# Altium schematic sheet TriangleGeneratorHammondFrontPnlRev1b.SchDoc(TriangleGeneratorHammondFrontPnlRev1b)
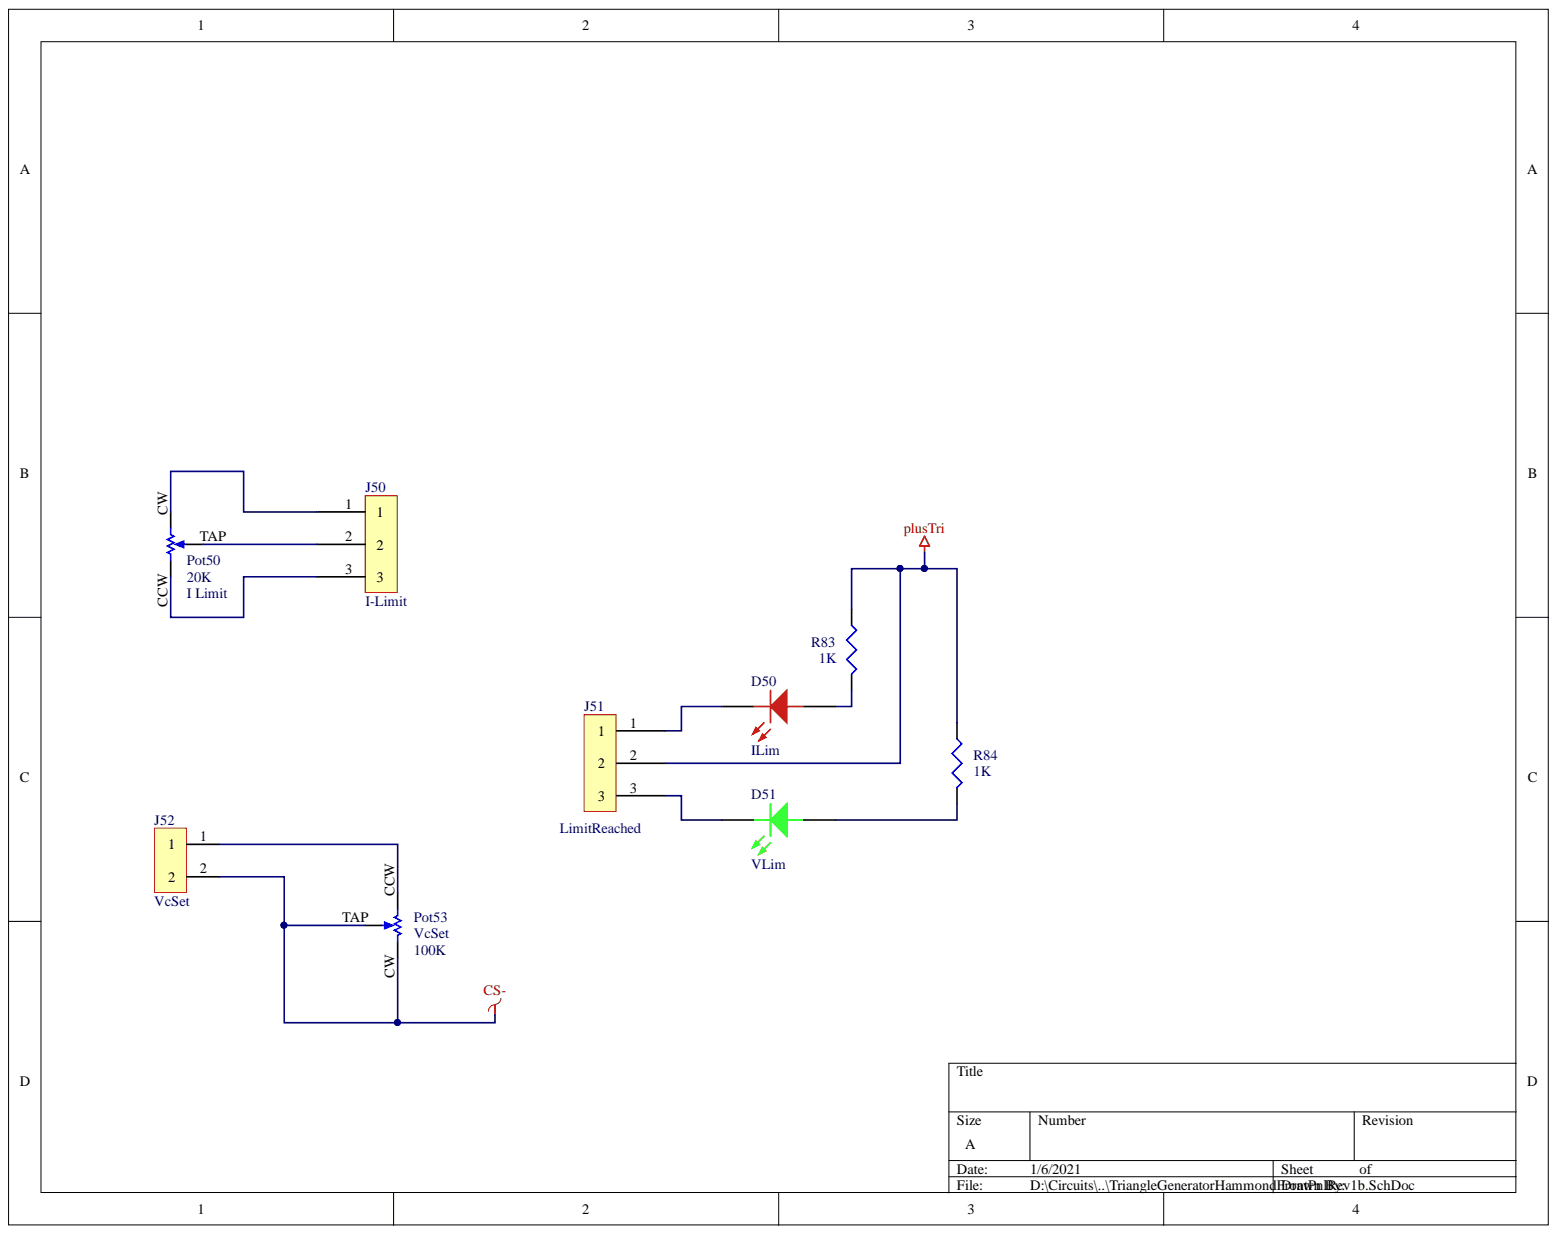
[diagram p0: TriangleGeneratorHammondFrontPnlRev1b.SchDoc]

## Parts
D50  ILim  [LED-RED-3MM]
D51  VLim  [LED-GRN-3MM]
J50  I-Limit  [DTA-100-3]
J51  LimitReached  [DTA-100-3]
J52  VcSet  [DTA-100-2]
Pot50  I Limit  value 20K  [Off-PCB]
Pot53  VcSet  value 100K  [Off-PCB]
R83  1K  [RESC3216M]
R84  1K  [RESC3216M]

## Nets
CS-: J52.2 Pot53.CW Pot53.TAP
plusTri: J51.2 R83.1 R84.1
NetD50_1: D50.1 R83.2
NetD50_2: D50.2 J51.1
NetD51_1: D51.1 R84.2
NetD51_2: D51.2 J51.3
NetJ50_1: J50.1 Pot50.CW
NetJ50_2: J50.2 Pot50.TAP
NetJ50_3: J50.3 Pot50.CCW
NetJ52_1: J52.1 Pot53.CCW

## Library data of the parts
D50 = Red: LED RED DIFFUSED T-1 3/4 T/H; Manufacturer Part Number: 4304H1; Manufacturer: VCC CHML; Wavelength: 650nm; Viewing Angle: 65°; Value: RED LED; Test Current: 10mA; Power Dissipation: 60mW; Number of Elements: 1; Luminous Intensity: 6.3mcd; Lens Style: Dome,Diffused,Round; Lens Size: 5mm; Forward Voltage: 2V; Dominant Wavelength: 640nm; Supplier Part Number: L71532-ND; Supplier: Digi-Key; REACH SVHC: Unknown; Packaging: Bulk; Package Quantity: 1000; Number of Pins: 2; Mount: Through Hole; Library Name: My_LED.SchLib; Lens Transparency: Diffused; Lens Color: Red; Length: 9.65mm; Lead Free: Lead Free; Illumination Color: Red; Height: 8.99mm; Forward Current: 10mA; Component Kind: Standard; Color: Red; Case/Package: Radial
D51 = Green: LED GREEN DIFF 5MM ROUND T/H; Manufacturer Part Number: 4304H5; Manufacturer: VCC CHML; Wavelength: 563nm; Viewing Angle: 75°; Value: Green LED; Test Current: 10mA; Power Dissipation: 75mW; Number of Elements: 1; Luminous Intensity: 10mcd; Lens Transparency: Diffused; Lens Style: Diffused,Tinted,Dome,Round; Forward Voltage: 2.1V; Supplier Part Number: L71533-ND; Supplier: Digi-Key; REACH SVHC: Unknown; Packaging: Bulk; Package Quantity: 1000; Number of Pins: 2; Mount: Through Hole; Library Name: My_LED.SchLib; Lens Color: Green; Length: 9.65mm; Lead Free: Lead Free; Illumination Color: Green; Height: 8.99mm; Forward Current: 10mA; Component Kind: Standard; Color: Green; Case/Package: Radial
J50 J51 = 3-Pin: CONN HEADER VERT 3POS 2.54MM; Manufacturer: TE Connectivity; Voltage Rating (AC): 250V; Value: 640456-3; Tail Length: 0.14inch; Strain Relief: No (Without); Plating Thickness: 3.81um; PCB Thickness: 0.063inch; PCB Mount Retention: Without; PCB Mounting Orientation: Vertical; Number of Positions: 3; Mating Connector Lock: Yes (With); Gender: Male; Current Rating: 5A; Contact Style: Straight; Contact Resistance: 6mR; Contact Post Length: 3.56mm; Connector Type: Connector,Pin,Plug,Header; Connector System: Wire-to-Board; Circuit Application: Signal; Approvals: CSA; Voltage Rating: 250V; Termination: Solder; Supplier Part Number: A19470-ND; Supplier: Digi-Key; Shrouded: No (Without); Sealable: No (Without); REACH SVHC: Unknown; Radiation Hardening: No; Pitch: 2.54mm; PCB Mount Alignment: No (Without); Panel Mount Retention: No (Without); Packaging: Bulk; Package Quantity: 5000; Orientation: Straight; Number of Rows: 1; Number of Contacts: 3; Mounting Ears: No (Without); Mount: Through Hole; Min Operating Temperature: -67°C; Max Voltage Rating (AC): 250V; Max Operating Temperature: 221°C; Mating Retention: No (Without); Mating Alignment: No (Without); Manufacturer Part Number: 640456-3; Library Name: MY_CONNECTORS.SchLib; Length: 0.4inch; Lead Free: Lead Free; Insulation Material: Thermoplastic; Insulation Color: Natural; Housing Material: Polyester; Housing Color: Natural; Height: 7.87mm; Flammability Rating: UL94 V-0; Fastening Type: Friction; ELV: Compliant; Depth: 5.72mm; Contact Shape: Square; Contact Retention: No (Without); Contact Plating: Tin; Contact Mating Length: 7.49mm; Contact Material: Copper Alloy; Contact Gender: Male; Contact Current Rating: 5A; Component Kind: Standard; Color: Beige,White; Backwall/Post Interruptions: No (Without)
J52 = 2-Pin: CONN HEADER VERT 2POS 2.54MM; Manufacturer: TE Connectivity; Working Voltage: 250V; Value: 640456-2; Tail Length: 0.14inch; Strain Relief: No (Without); Plating Thickness: 3.81um; PCB Thickness: 0.063inch; PCB Mount Retention: Without; PCB Mounting Orientation: Vertical; Number of Positions: 2; Mating Connector Lock: Yes (With); Gender: Male; Current Rating: 5A; Contact Style: Straight; Contact Resistance: 6mR; Contact Post Length: 3.56mm; Connector Type: Connector,Pin,Plug,Header; Connector System: Wire-to-Board; Circuit Application: Signal; Approvals: CSA; Voltage Rating (AC): 250V; Voltage Rating: 250V; Termination: Solder; Supplier Part Number: A1921-ND; Supplier: Digi-Key; Shrouded: No (Without); Sealable: No (Without); REACH SVHC: No SVHC; Radiation Hardening: No; Pitch: 2.54mm; PCB Mount Alignment: No (Without); Panel Mount Retention: No (Without); Packaging: Bulk; Package Quantity: 5000; Orientation: Straight; Number of Rows: 1; Number of Poles: 2; Number of Contacts: 2; Mounting Ears: No (Without); Mount: Through Hole; Min Operating Temperature: -67°C; Max Voltage Rating (AC): 250V; Max Operating Temperature: 221°C; Mating Retention: No (Without); Mating Alignment: No (Without); Manufacturer Part Number: 640456-2; Library Name: MY_CONNECTORS.SchLib; Length: 7.62mm; Lead Pitch: 2.54mm; Lead Free: Lead Free; Insulation Material: Thermoplastic; Insulation Color: Natural; Housing Material: Polyester; Housing Color: Natural; Height: 7.87mm; Flammability Rating: UL94 V-0; Fastening Type: Friction; ELV: Compliant; Depth: 5.72mm; Contact Shape: Square; Contact Retention: No (Without); Contact Plating: Tin; Contact Mating Length: 7.49mm; Contact Material: Copper Alloy; Contact Gender: Male; Contact Current Rating: 5A; Component Kind: Standard; Color: Natural,White,Beige; Backwall/Post Interruptions: No (Without)
Pot50 = POT-Single: PNL MNT 20K OHM 0.125W; Manufacturer Part Number: RV16AF-10-15R1-B20K-3LA; Manufacturer: Alpha Taiwan; Supplier Part Number: 313-1000F-20K; Supplier: Mouser; Component Kind: Standard; Library Name: MY_POTS.SchLib
Pot53 = POT-Single: PNL MNT 100K OHM 0.125W; Manufacturer Part Number: RV16AF-10-15R1-B100K-3LA; Manufacturer: Alpha Taiwan; Supplier Part Number: 313-1000F-100K; Supplier: Mouser; Component Kind: Standard; Library Name: MY_POTS.SchLib
R83 R84 = Res3: RES 1K OHM 1% 1/4W 1206; Manufacturer Part Number: RMCF1206FT1K00; Manufacturer: Stackpole Electronics; Temperature Coefficient: 100ppm/°C; Resistance: 1kR; Power Rating: 250mW; Width: 0.063inch; Value: 1K; Tolerance: 1%; Supplier Part Number: RMCF1206FT1K00CT-ND; Supplier: Digi=Key; Simulation: RESISTOR; Signal Integrity: Res; Radiation Hardening: No; Packaging: Tape and Reel; Number of Terminations: 2; Mount: Surface Mount; Min Operating Temperature: -55°C; Military Standard: Not; Max Power Dissipation: 250mW; Max Operating Temperature: 155°C; Library Name: Miscellaneous Devices.IntLib; Length: 3.2mm; Lead Free: Lead Free; Height: 0.028inch; Features: Automotive AEC-Q200; Depth: 1.6mm; Contact Plating: Matte,Tin; Composition: Thick Film; Component Kind: Standard; Case Code (Metric): 3216; Case Code (Imperial): 1206; Case/Package: 1206
